# Altium schematic sheet lv_mcu_ios_100_power.SchDoc
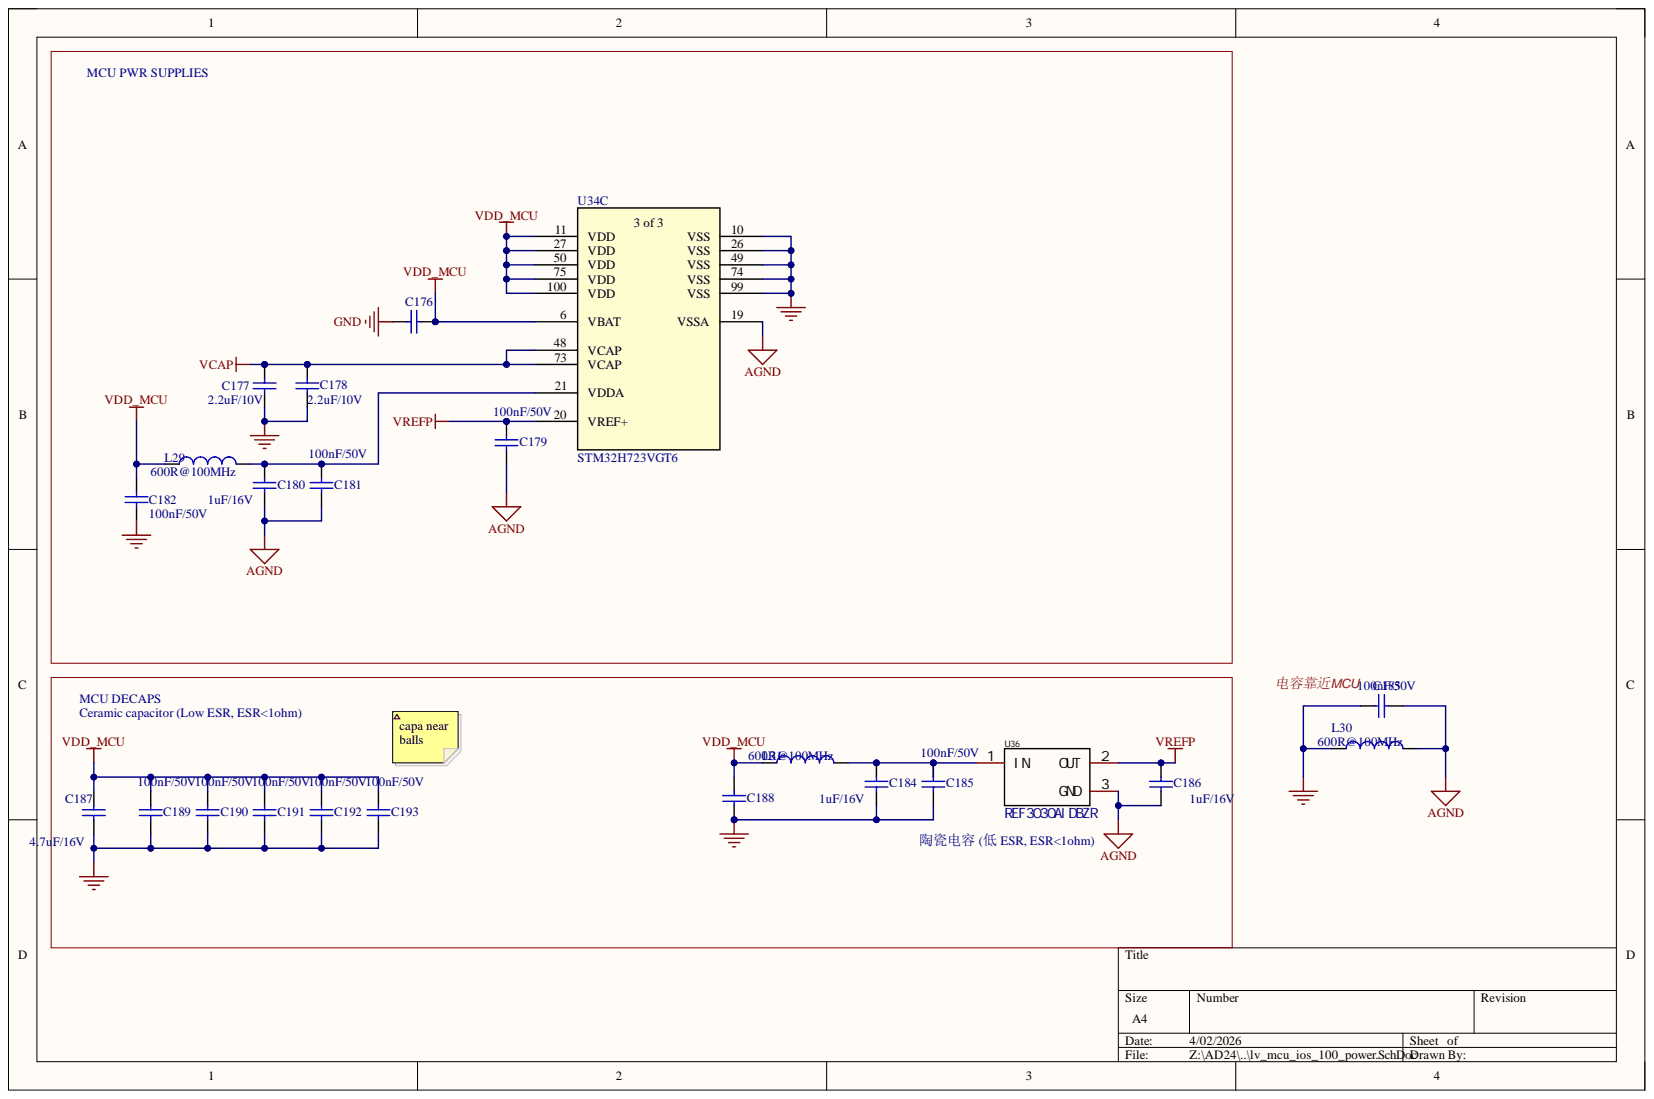
[diagram p0: lv_mcu_ios_100_power.SchDoc]

## Parts
C176  1uF/16V  [C0603]  (value not drawn)
C177  2.2uF/10V  [C0603]
C178  2.2uF/10V  [C0603]
C179  100nF/50V  [C0402]
C180  1uF/16V  [C0603]
C181  100nF/50V  [C0402]
C182  100nF/50V  [C0402]
C183  100nF/50V  [C0402]
C184  1uF/16V  [C0603]
C185  100nF/50V  [C0402]
C186  1uF/16V  [C0603]
C187  4.7uF/16V  [C0603]
C188  100nF/50V  [C0402]
C189  100nF/50V  [C0402]
C190  100nF/50V  [C0402]
C191  100nF/50V  [C0402]
C192  100nF/50V  [C0402]
C193  100nF/50V  [C0402]
L29  600R@100MHz  [L0603]
L30  600R@100MHz  [L0603]
L31  600R@100MHz  [L0603]
U34  STM32H723VGT6  [LQFP100_STM-L]  (part C)
U36  REF3030AIDBZR  [SOT-23-3_L2.9-W1.6-P1.90-LS2.8-BR]

## Nets
AGND: C179.1 C180.2 C181.1 C183.1 C186.1 L30.2 U34.19(VSSA) U36.3
GND: C176.2 C177.1 C178.1 C182.2 C183.2 C184.1 C185.1 C187.1 C188.1 C189.1 C190.1 C191.1 C192.1 C193.1 L30.1 U34.10(VSS) U34.26(VSS) U34.49(VSS) U34.74(VSS) U34.99(VSS)
VCAP: C177.2 C178.2 U34.48(VCAP) U34.73(VCAP)
VDD_MCU: C176.1 C182.1 C187.2 C188.2 C189.2 C190.2 C191.2 C192.2 C193.2 L29.1 L31.1 U34.6(VBAT) U34.11(VDD) U34.27(VDD) U34.50(VDD) U34.75(VDD) U34.100(VDD)
VREFP: C179.2 C186.2 U34.20(VREF+) U36.2
NetC180_1: C180.1 C181.2 L29.2 U34.21(VDDA)
NetC184_2: C184.2 C185.2 L31.2 U36.1

## Library data of the parts
C176 = MCD-00238: 精度±10%,温度系数X5R; Manufacturer: YAGEO; Manufacturer_Part_Number: CC0603KRX5R7BB105; Database Table Name: MCD_PARTS$; Component Kind: Standard; ComponentLink1Description: Datasheet; ComponentLink1URL: http://tunmcdsvn.tun.st.com/Component_Datasheet_Library/Trunk/Capacitors/Yageo_Multilayer_capacitor_X5R_series_100pF_to_100uF; Library Name: MCD_PARTS_database.DbLib
C177 = MCD-00012: 10%,X5R; Manufacturer: YAGEO; Manufacturer_Part_Number: CC0603KRX5R6BB225; Database Table Name: MCD_PARTS$; Component Kind: Standard; ComponentLink1Description: Datasheet; ComponentLink1URL: http://tunmcdsvn.tun.st.com/Component_Datasheet_Library/Trunk/Capacitors/Yageo_Multilayer_capacitor_X5R_series_100pF_to_100uF; Library Name: MCD_PARTS_database.DbLib
C178 = MCD-00012: X5R, 10%; Alternate_Manufacturer_Part_Number: CC0603KRX5R6BB225; Manufacturer: YAGEO; Database Table Name: MCD_PARTS$; Component Kind: Standard; ComponentLink1Description: Datasheet; ComponentLink1URL: http://tunmcdsvn.tun.st.com/Component_Datasheet_Library/Trunk/Capacitors/Yageo_Multilayer_capacitor_X5R_series_100pF_to_100uF; Library Name: MCD_PARTS_database.DbLib
C179 C181 C189-C192 = MCD-01123: X7R, 10%; Manufacturer: MURATA; Manufacturer_Part_Number: GRM155R71H104KE14D; Database Table Name: MCD_PARTS$; ECCN_EU: NEC; ECCN_US: EAR99; Component Kind: Standard; ComponentLink1Description: Datasheet; ComponentLink1URL: http://tunmcdsvn.tun.st.com/Component_Datasheet_Library/Trunk/Capacitors/Murata_Capacitor_GR_series; Library Name: MCD_PARTS_database.DbLib
C180 = MCD-00238: X5R, 10%; Manufacturer: YAGEO; Manufacturer_Part_Number: CC0603KRX5R7BB105; Database Table Name: MCD_PARTS$; Component Kind: Standard; ComponentLink1Description: Datasheet; ComponentLink1URL: http://tunmcdsvn.tun.st.com/Component_Datasheet_Library/Trunk/Capacitors/Yageo_Multilayer_capacitor_X5R_series_100pF_to_100uF; Library Name: MCD_PARTS_database.DbLib
C182 = MCD-00006: X7R, 10%; Alternate_Manufacturer: MURATA; Alternate_Manufacturer_Part_Number: GCM155R71C104KA55D; Manufacturer_Part_Number: GRM155R71H104KE14D; Database Table Name: MCD_PARTS$; ECCN_EU: NEC; ECCN_US: EAR99; Component Kind: Standard; ComponentLink1Description: Datasheet; ComponentLink1URL: http://tunmcdsvn.tun.st.com/Component_Datasheet_Library/Trunk/Capacitors/Yageo_Multilayer_Capacitor_X7R_series_100pF_to_22uF; Library Name: MCD_PARTS_database.DbLib; Manufacturer: MURATA
C183 = MCD-00006: X7R, 10%; Alternate_Manufacturer: MURATA; Alternate_Manufacturer_Part_Number: GCM155R71C104KA55D; Manufacturer_Part_Number: GRM155R71H104KE14D; Database Table Name: MCD_PARTS$; Initial_Board_Reference: MB1274; Item Type: Item; Path: ws/MCD_ApplicationBoards/Lists/MCDPARTSdatabase; Component Kind: Standard; ComponentLink1Description: Datasheet; ComponentLink1URL: http://tunmcdsvn.tun.st.com/Component_Datasheet_Library/Trunk/Capacitors/Yageo_Multilayer_Capacitor_X7R_series_100pF_to_22uF; Library Name: MCD_PARTS_database.DbLib; Manufacturer: MURATA
C184 C186 = MCD-00010: X5R, 10%; Manufacturer: YAGEO; Manufacturer_Part_Number: CC0603KRX5R7BB105; Component Kind: Standard; Library Name: MB1397.IntLib
  C186: ComponentLink1Description: Datasheet; ComponentLink1URL: http://tunmcdsvn.tun.st.com/Component_Datasheet_Library/Trunk/Capacitors/Yageo_Multilayer_capacitor_X5R_series_100pF_to_100uF
C185 = MCD-00006: X7R, 10%; Alternate_Manufacturer: MURATA; Alternate_Manufacturer_Part_Number: GCM155R71C104KA55D; Manufacturer_Part_Number: GRM155R71H104KE14D; Initial_Board_Reference: MB1274; Item Type: Item; Path: ws/MCD_ApplicationBoards/Lists/MCDPARTSdatabase; Component Kind: Standard; ComponentLink1Description: Datasheet; ComponentLink1URL: http://tunmcdsvn.tun.st.com/Component_Datasheet_Library/Trunk/Capacitors/Yageo_Multilayer_Capacitor_X7R_series_100pF_to_22uF; Library Name: MB1397.IntLib; Manufacturer: MURATA
C187 = MCD-01121: 16V, X5R, 20%,; Manufacturer: MURATA; Manufacturer_Part_Number: GRM188R61C475KE11D; Database Table Name: MCD_PARTS$; Component Kind: Standard; ComponentLink1Description: Datasheet; ComponentLink1URL: http://tunmcdsvn.tun.st.com/Component_Datasheet_Library/Trunk/Capacitors/Murata_Capacitor_GR_series; Library Name: MCD_PARTS_database.DbLib
C188 = MCD-00102: X7R, 10%; Manufacturer: MURATA; Manufacturer_Part_Number: GRM155R71H104KE14D; Database Table Name: MCD_PARTS$; Component Kind: Standard; ComponentLink1Description: Yageo_Capacitor_X7R-series.pdf; ComponentLink1URL: http://tunmcdsvn.tun.st.com/Component_Datasheet_Library/Trunk/Capacitors/Yageo_Multilayer_Capacitor_X7R_series_100pF_to_22uF; Library Name: MCD_PARTS_database.DbLib
C193 = MCD-01123: X7R, 10%; Manufacturer: MURATA; Manufacturer_Part_Number: GRM155R71H104KE14D; Database Table Name: MCD_PARTS$; Component Kind: Standard; ComponentLink1Description: Datasheet; ComponentLink1URL: http://tunmcdsvn.tun.st.com/Component_Datasheet_Library/Trunk/Capacitors/Murata_Capacitor_GR_series; Library Name: MCD_PARTS_database.DbLib
L29 L31 = MCD-00047: +/-25%, 350mA, 500mohm DC; Manufacturer_Part_Number: FCM1608KF-601T03; Database Table Name: MCD_PARTS$; Component Kind: Standard; ComponentLink1Description: Datasheet; ComponentLink1URL: http://tunmcdsvn.tun.st.com/Component_Datasheet_Library/Trunk/Inductors/TaiTech_Bead_FCM1608_series; ECCN_EU: n/a; ECCN_US: n/a; Library Name: MCD_PARTS_database.DbLib
L30 = MCD-00047: +/-25%, 350mA, 500mohm DC; Manufacturer_Part_Number: FCM1608KF-601T03; Database Table Name: MCD_PARTS$; Initial_Board_Reference: MB1274; Item Type: Item; Path: ws/MCD_ApplicationBoards/Lists/MCDPARTSdatabase; Component Kind: Standard; ComponentLink1Description: Datasheet; ComponentLink1URL: http://tunmcdsvn.tun.st.com/Component_Datasheet_Library/Trunk/Inductors/TaiTech_Bead_FCM1608_series; Library Name: MCD_PARTS_database.DbLib
U34 = STM32H723VGT6: No Description Available; Mfr_Name: STMicroelectronics; Component Kind: Standard; Library Name: HiPin_Library_AD.IntLib; RefDes: RefDes; Type: Type
U36 = REF3030AIDBZR: Manufacturer: TI(德州仪器); Add into BOM: yes; Component Kind: Standard; Convert to PCB: yes; Datasheet: https://atta.szlcsc.com/upload/public/pdf/source/20141125/1457707248562.pdf; Device: REF3030AIDBZR; JLCPCB Part Class: Extended Part; LCSC Part Name: REF3030AIDBZR; Library Name: HiPin_Library_AD.IntLib; Manufacturer Part: REF3030AIDBZR; Name: REF3030AIDBZR; PCB3D: SOT-23-3L_L2.9-W1.6-H1.1-LS2.8-P0.95; Supplier: LCSC; Supplier Footprint: SOT-23; Supplier Part: C38423; Symbol: REF3030AIDBZR
